# Altium schematic sheet STAMP Interface.SchDoc("STAMP Interface")
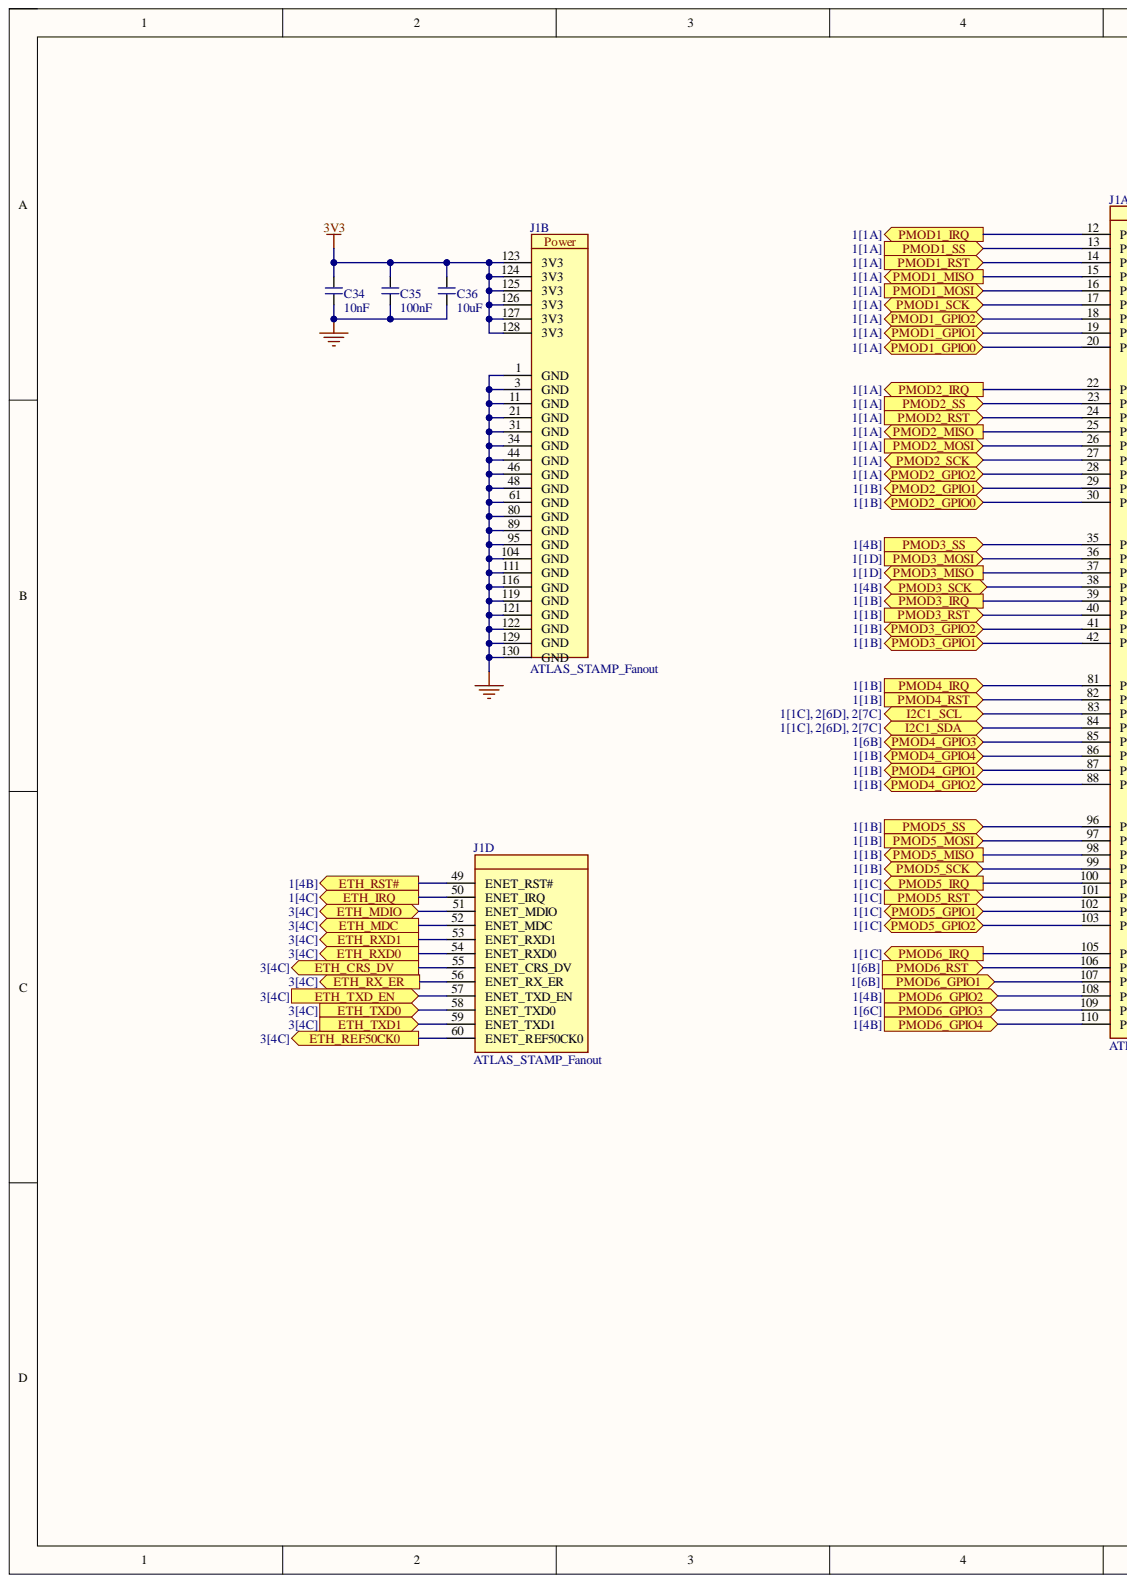
[diagram p0: STAMP Interface.SchDoc, left]
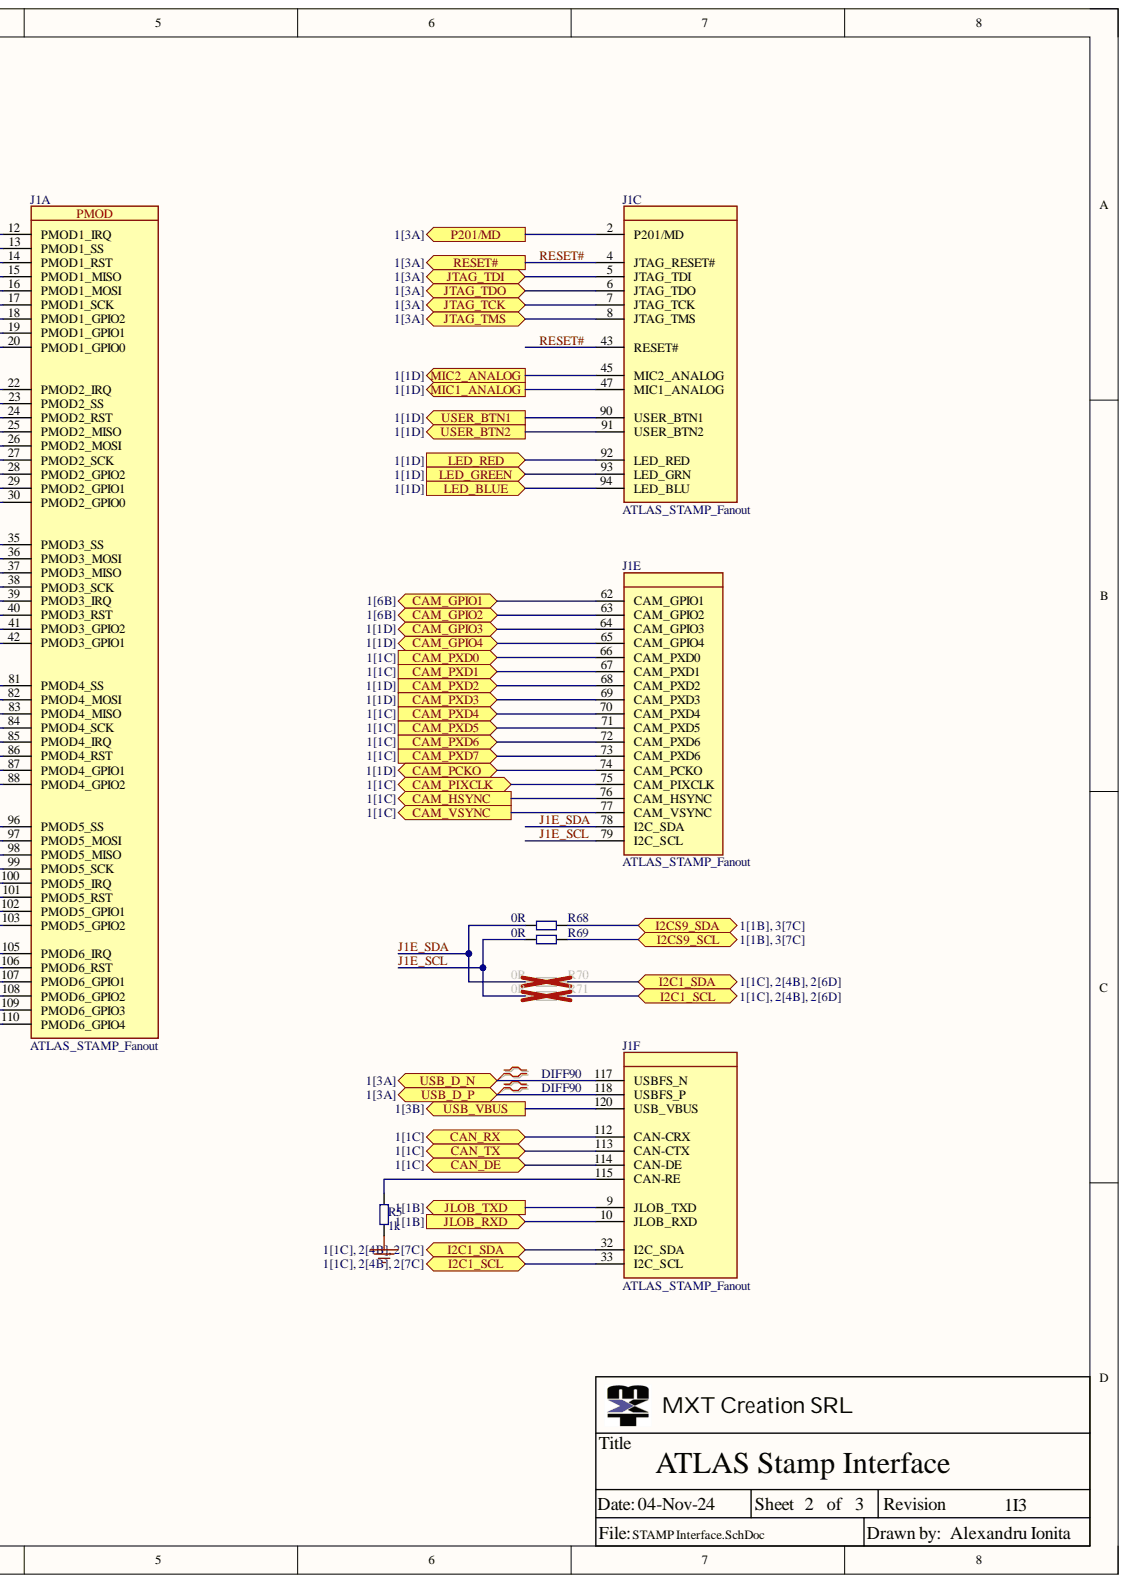
[diagram p1: STAMP Interface.SchDoc, right]

## Parts
C34  10nF  [CAP0402]
C35  100nF  [CAP0402]
C36  10uF  [CAP0402]
J1  ATLAS_STAMP_Fanout  [ATLAS_STAMP_FANOUT]  (parts A,B,C,D,E,F)
R5  1k  [RES0402]
R68  0R  [RES0603]
R69  0R  [RES0603]
R70  0R  [RES0603]
R71  0R  [RES0603]

## Ports
CAM_GPIO1, CAM_GPIO2, CAM_GPIO3, CAM_GPIO4, CAM_HSYNC, CAM_PCKO, CAM_PIXCLK, CAM_PXD0, CAM_PXD1, CAM_PXD2, CAM_PXD3, CAM_PXD4, CAM_PXD5, CAM_PXD6, CAM_PXD7, CAM_VSYNC, CAN_DE, CAN_RX, CAN_TX, ETH_CRS_DV, ETH_IRQ, ETH_MDC, ETH_MDIO, ETH_REF50CK0, ETH_RST#, ETH_RX_ER, ETH_RXD0, ETH_RXD1, ETH_TXD0, ETH_TXD1, ETH_TXD_EN, I2C1_SCL, I2C1_SDA, I2CS9_SCL, I2CS9_SDA, JLOB_RXD, JLOB_TXD, JTAG_TCK, JTAG_TDI, JTAG_TDO, JTAG_TMS, LED_BLUE, LED_GREEN, LED_RED, MIC1_ANALOG, MIC2_ANALOG, P201/MD, PMOD1_GPIO0, PMOD1_GPIO1, PMOD1_GPIO2, PMOD1_IRQ, PMOD1_MISO, PMOD1_MOSI, PMOD1_RST, PMOD1_SCK, PMOD1_SS, PMOD2_GPIO0, PMOD2_GPIO1, PMOD2_GPIO2, PMOD2_IRQ, PMOD2_MISO, PMOD2_MOSI, PMOD2_RST, PMOD2_SCK, PMOD2_SS, PMOD3_GPIO1, PMOD3_GPIO2, PMOD3_IRQ, PMOD3_MISO, PMOD3_MOSI, PMOD3_RST, PMOD3_SCK, PMOD3_SS, PMOD4_GPIO1, PMOD4_GPIO2, PMOD4_GPIO3, PMOD4_GPIO4, PMOD4_IRQ, PMOD4_RST, PMOD5_GPIO1, PMOD5_GPIO2, PMOD5_IRQ, PMOD5_MISO, PMOD5_MOSI, PMOD5_RST, PMOD5_SCK, PMOD5_SS, PMOD6_GPIO1, PMOD6_GPIO2, PMOD6_GPIO3, PMOD6_GPIO4, PMOD6_IRQ, PMOD6_RST, RESET#, USB_D_N, USB_D_P, USB_VBUS, USER_BTN1, USER_BTN2

## Nets
3V3: C34.2 C35.2 C36.2 J1.123(3V3) J1.124(3V3) J1.125(3V3) J1.126(3V3) J1.127(3V3) J1.128(3V3)
GND: C34.1 C35.1 C36.1 J1.1(GND) J1.3(GND) J1.11(GND) J1.21(GND) J1.31(GND) J1.34(GND) J1.44(GND) J1.46(GND) J1.48(GND) J1.61(GND) J1.80(GND) J1.89(GND) J1.95(GND) J1.104(GND) J1.111(GND) J1.116(GND) J1.119(GND) J1.121(GND) J1.122(GND) J1.129(GND) J1.130(GND) R5.1
CAM_GPIO1: J1.62(CAM_GPIO1) ; port CAM_GPIO1
CAM_GPIO2: J1.63(CAM_GPIO2) ; port CAM_GPIO2
CAM_GPIO3: J1.64(CAM_GPIO3) ; port CAM_GPIO3
CAM_GPIO4: J1.65(CAM_GPIO4) ; port CAM_GPIO4
CAM_HSYNC: J1.76(CAM_HSYNC) ; port CAM_HSYNC
CAM_PCKO: J1.74(CAM_PCKO) ; port CAM_PCKO
CAM_PIXCLK: J1.75(CAM_PIXCLK) ; port CAM_PIXCLK
CAM_PXD0: J1.66(CAM_PXD0) ; port CAM_PXD0
CAM_PXD1: J1.67(CAM_PXD1) ; port CAM_PXD1
CAM_PXD2: J1.68(CAM_PXD2) ; port CAM_PXD2
CAM_PXD3: J1.69(CAM_PXD3) ; port CAM_PXD3
CAM_PXD4: J1.70(CAM_PXD4) ; port CAM_PXD4
CAM_PXD5: J1.71(CAM_PXD5) ; port CAM_PXD5
CAM_PXD6: J1.72(CAM_PXD6) ; port CAM_PXD6
CAM_PXD7: J1.73(CAM_PXD6) ; port CAM_PXD7
CAM_VSYNC: J1.77(CAM_VSYNC) ; port CAM_VSYNC
CAN_DE: J1.114(CAN-DE) ; port CAN_DE
CAN_RX: J1.112(CAN-CRX) ; port CAN_RX
CAN_TX: J1.113(CAN-CTX) ; port CAN_TX
ETH_CRS_DV: J1.55(ENET_CRS_DV) ; port ETH_CRS_DV
ETH_IRQ: J1.50(ENET_IRQ) ; port ETH_IRQ
ETH_MDC: J1.52(ENET_MDC) ; port ETH_MDC
ETH_MDIO: J1.51(ENET_MDIO) ; port ETH_MDIO
ETH_REF50CK0: J1.60(ENET_REF50CK0) ; port ETH_REF50CK0
ETH_RST#: J1.49(ENET_RST#) ; port ETH_RST#
ETH_RX_ER: J1.56(ENET_RX_ER) ; port ETH_RX_ER
ETH_RXD0: J1.54(ENET_RXD0) ; port ETH_RXD0
ETH_RXD1: J1.53(ENET_RXD1) ; port ETH_RXD1
ETH_TXD0: J1.58(ENET_TXD0) ; port ETH_TXD0
ETH_TXD1: J1.59(ENET_TXD1) ; port ETH_TXD1
ETH_TXD_EN: J1.57(ENET_TXD_EN) ; port ETH_TXD_EN
I2C1_SCL: J1.33(I2C_SCL) J1.83(PMOD4_MISO) R71.2 ; port I2C1_SCL
I2C1_SDA: J1.32(I2C_SDA) J1.84(PMOD4_SCK) R70.2 ; port I2C1_SDA
I2CS9_SCL: R69.2 ; port I2CS9_SCL
I2CS9_SDA: R68.2 ; port I2CS9_SDA
J1E_SCL: J1.79(I2C_SCL) R69.1 R71.1
J1E_SDA: J1.78(I2C_SDA) R68.1 R70.1
JLOB_RXD: J1.10(JLOB_RXD) ; port JLOB_RXD
JLOB_TXD: J1.9(JLOB_TXD) ; port JLOB_TXD
JTAG_TCK: J1.7(JTAG_TCK) ; port JTAG_TCK
JTAG_TDI: J1.5(JTAG_TDI) ; port JTAG_TDI
JTAG_TDO: J1.6(JTAG_TDO) ; port JTAG_TDO
JTAG_TMS: J1.8(JTAG_TMS) ; port JTAG_TMS
LED_BLUE: J1.94(LED_BLU) ; port LED_BLUE
LED_GREEN: J1.93(LED_GRN) ; port LED_GREEN
LED_RED: J1.92(LED_RED) ; port LED_RED
MIC1_ANALOG: J1.47(MIC1_ANALOG) ; port MIC1_ANALOG
MIC2_ANALOG: J1.45(MIC2_ANALOG) ; port MIC2_ANALOG
P201/MD: J1.2(P201/MD) ; port P201/MD
PMOD1_GPIO0: J1.20(PMOD1_GPIO0) ; port PMOD1_GPIO0
PMOD1_GPIO1: J1.19(PMOD1_GPIO1) ; port PMOD1_GPIO1
PMOD1_GPIO2: J1.18(PMOD1_GPIO2) ; port PMOD1_GPIO2
PMOD1_IRQ: J1.12(PMOD1_IRQ) ; port PMOD1_IRQ
PMOD1_MISO: J1.15(PMOD1_MISO) ; port PMOD1_MISO
PMOD1_MOSI: J1.16(PMOD1_MOSI) ; port PMOD1_MOSI
PMOD1_RST: J1.14(PMOD1_RST) ; port PMOD1_RST
PMOD1_SCK: J1.17(PMOD1_SCK) ; port PMOD1_SCK
PMOD1_SS: J1.13(PMOD1_SS) ; port PMOD1_SS
PMOD2_GPIO0: J1.30(PMOD2_GPIO0) ; port PMOD2_GPIO0
PMOD2_GPIO1: J1.29(PMOD2_GPIO1) ; port PMOD2_GPIO1
PMOD2_GPIO2: J1.28(PMOD2_GPIO2) ; port PMOD2_GPIO2
PMOD2_IRQ: J1.22(PMOD2_IRQ) ; port PMOD2_IRQ
PMOD2_MISO: J1.25(PMOD2_MISO) ; port PMOD2_MISO
PMOD2_MOSI: J1.26(PMOD2_MOSI) ; port PMOD2_MOSI
PMOD2_RST: J1.24(PMOD2_RST) ; port PMOD2_RST
PMOD2_SCK: J1.27(PMOD2_SCK) ; port PMOD2_SCK
PMOD2_SS: J1.23(PMOD2_SS) ; port PMOD2_SS
PMOD3_GPIO1: J1.42(PMOD3_GPIO1) ; port PMOD3_GPIO1
PMOD3_GPIO2: J1.41(PMOD3_GPIO2) ; port PMOD3_GPIO2
PMOD3_IRQ: J1.39(PMOD3_IRQ) ; port PMOD3_IRQ
PMOD3_MISO: J1.37(PMOD3_MISO) ; port PMOD3_MISO
PMOD3_MOSI: J1.36(PMOD3_MOSI) ; port PMOD3_MOSI
PMOD3_RST: J1.40(PMOD3_RST) ; port PMOD3_RST
PMOD3_SCK: J1.38(PMOD3_SCK) ; port PMOD3_SCK
PMOD3_SS: J1.35(PMOD3_SS) ; port PMOD3_SS
PMOD4_GPIO1: J1.87(PMOD4_GPIO1) ; port PMOD4_GPIO1
PMOD4_GPIO2: J1.88(PMOD4_GPIO2) ; port PMOD4_GPIO2
PMOD4_GPIO3: J1.85(PMOD4_IRQ) ; port PMOD4_GPIO3
PMOD4_GPIO4: J1.86(PMOD4_RST) ; port PMOD4_GPIO4
PMOD4_IRQ: J1.81(PMOD4_SS) ; port PMOD4_IRQ
PMOD4_RST: J1.82(PMOD4_MOSI) ; port PMOD4_RST
PMOD5_GPIO1: J1.102(PMOD5_GPIO1) ; port PMOD5_GPIO1
PMOD5_GPIO2: J1.103(PMOD5_GPIO2) ; port PMOD5_GPIO2
PMOD5_IRQ: J1.100(PMOD5_IRQ) ; port PMOD5_IRQ
PMOD5_MISO: J1.98(PMOD5_MISO) ; port PMOD5_MISO
PMOD5_MOSI: J1.97(PMOD5_MOSI) ; port PMOD5_MOSI
PMOD5_RST: J1.101(PMOD5_RST) ; port PMOD5_RST
PMOD5_SCK: J1.99(PMOD5_SCK) ; port PMOD5_SCK
PMOD5_SS: J1.96(PMOD5_SS) ; port PMOD5_SS
PMOD6_GPIO1: J1.107(PMOD6_GPIO1) ; port PMOD6_GPIO1
PMOD6_GPIO2: J1.108(PMOD6_GPIO2) ; port PMOD6_GPIO2
PMOD6_GPIO3: J1.109(PMOD6_GPIO3) ; port PMOD6_GPIO3
PMOD6_GPIO4: J1.110(PMOD6_GPIO4) ; port PMOD6_GPIO4
PMOD6_IRQ: J1.105(PMOD6_IRQ) ; port PMOD6_IRQ
PMOD6_RST: J1.106(PMOD6_RST) ; port PMOD6_RST
RESET#: J1.4(JTAG_RESET#) J1.43(RESET#) ; port RESET#
USB_D_N: J1.117(USBFS_N) ; port USB_D_N
USB_D_P: J1.118(USBFS_P) ; port USB_D_P
USB_VBUS: J1.120(USB_VBUS) ; port USB_VBUS
USER_BTN1: J1.90(USER_BTN1) ; port USER_BTN1
USER_BTN2: J1.91(USER_BTN2) ; port USER_BTN2
NetJ1_115: J1.115(CAN-RE) R5.2

## Library data of the parts
C34 = GRM155R71H103KA88J: CAP CER 10000PF 50V X7R 0402; Manufacturer: Murata; Component Kind: Standard; Manufacturer Part Number: GRM155R71H103KA88J; Library Name: MXT_Library.IntLib
C35 = GRM155R71E104KE14J: CAP CER 0.1UF 10% 25V X7R 0402; Manufacturer: Murata; .Checked_PCB: AI; Component Kind: Standard; Manufacturer Part Number: GRM155R71E104KE14J; .Checked_SCH: AI; .Creator: AI; Library Name: MXT_Library.IntLib
C36 = GRM155R60J106ME47D: CAP CER 10UF 20% 6.3V X5R 0402; Manufacturer: Murata; .Checked_PCB: AI; Component Kind: Standard; Manufacturer Part Number: GRM155R60J106ME47D; .Checked_SCH: AI; .Creator: AI; Library Name: MXT_Library.IntLib
R5 = RC0402FR-071KL: RES SMD 1K OHM 1% 1/16W 0402; Manufacturer: Yageo; Component Kind: Standard; Manufacturer Part Number: RC0402FR-071KL; Library Name: MXT_Library.IntLib
R68-R71 = RC0603FR-070RL: RES SMD 0 OHM 1% 1/10W 0603; Manufacturer: Yageo; .Checked_PCB: IC; Component Kind: Standard; Manufacturer Part Number: RC0603FR-070RL; .Checked_SCH: IC; .Creator: IC; Library Name: MXT_Library.IntLib
J1 = ATLAS_STAMP_Fanout: Component Kind: Standard; Library Name: MXT_Library.SchLib
